# Altium schematic sheet main.SchDoc(main)
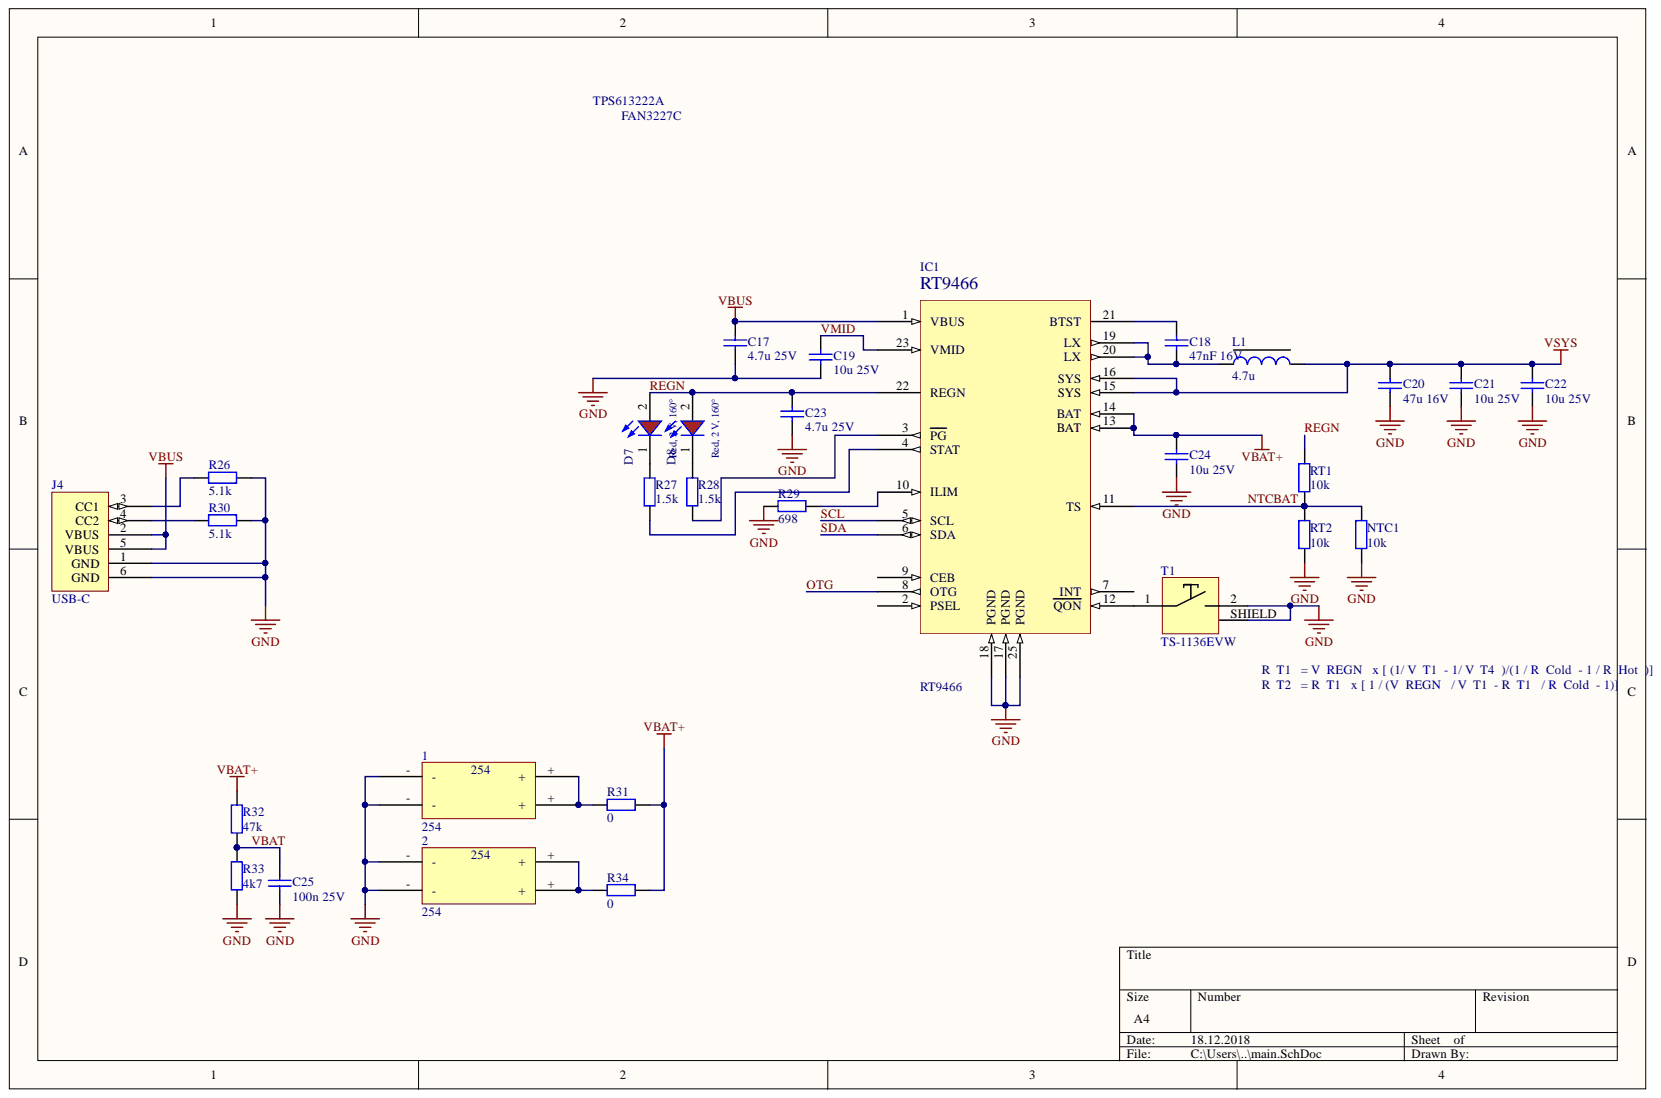
[diagram p0: main.SchDoc]

## Parts
1  254  [254]
2  254  [254]
C17  4.7u 25V  [C-0805-H]
C18  47nF 16V  [C-0603-M]
C19  10u 25V  [C-0805-H]
C20  47u 16V  [C-1210-M]
C21  10u 25V  [C-0805-H]
C22  10u 25V  [C-0805-H]
C23  4.7u 25V  [C-0805-H]
C24  10u 25V  [C-0805-H]
C25  100n 25V  [C-0603-H]
D7  Red, 2 V, 160°  [WL-SMRD_1205_reverse use]
D8  Red, 2 V, 160°  Viewing Angle: 160°  [WL-SMRD_1205_reverse use]
IC1  RT9466  [RT9466]
J4  USB-C  [5362]
L1  4.7u  [LPS4012]
NTC1  10k  [R-0603-H]
R26  5.1k  [R-0603-H]
R27  1.5k  [R-0603-M]
R28  1.5k  [R-0603-M]
R29  698  [R-0603-M]
R30  5.1k  [R-0603-H]
R31  0  [R-1206-M]
R32  47k  [R-0603-H]
R33  4k7  [R-0603-H]
R34  0  [R-1206-M]
RT1  10k  [R-0603-H]
RT2  10k  [R-0603-H]
T1  TS-1136EVW  [TS-1136EVW]

## Nets
GND: 1.- 2.- C17.2 C19.1 C20.1 C21.1 C22.1 C23.1 C24.1 C25.1 IC1.17(PGND) IC1.18(PGND) IC1.25(PGND) J4.1(GND) J4.6(GND) NTC1.1 R26.1 R29.1 R30.1 R33.1 RT2.1 T1.2 T1.SHIELD
VBAT+: C24.2 IC1.13(BAT) IC1.14(BAT) R31.1 R32.2 R34.1
VBUS: C17.1 IC1.1(VBUS) J4.2(VBUS) J4.5(VBUS)
VSYS: C20.2 C21.2 C22.2 IC1.15(SYS) IC1.16(SYS) L1.2
NTCBAT: IC1.11(TS) NTC1.2 RT1.1 RT2.2
OTG: IC1.8(OTG)
REGN: C23.2 D7.2 D8.2 IC1.22(REGN) RT1.2
SCL: IC1.5(SCL)
SDA: IC1.6(SDA)
VBAT: C25.2 R32.1 R33.2
VMID: C19.2 IC1.23(VMID)
Net1_+: 1.+ R31.2
Net2_+: 2.+ R34.2
NetC18_1: C18.1 IC1.19(LX) IC1.20(LX) L1.1
NetC18_2: C18.2 IC1.21(BTST)
NetD7_1: D7.1 R27.1
NetD8_1: D8.1 R28.1
NetIC1_3: IC1.3(PG) R28.2
NetIC1_4: IC1.4(STAT) R27.2
NetIC1_10: IC1.10(ILIM) R29.2
NetIC1_12: IC1.12(QON) T1.1
NetJ4_3: J4.3(CC1) R26.2
NetJ4_4: J4.4(CC2) R30.2

Not connected: IC1 2(PSEL) 7(INT) 9(CEB)

## Library data of the parts
1 2 = 254: Keystone 254; Library Name: 254.SchLib; Component Kind: Standard
C17 C23 = C-0805-4u7-25V: Capacitor 4.7u 25V; Supplier Part Number 1: 80-C0805C475K3P; Supplier 1: Mouser; Library Name: Capacitors-0805.SchLib; Component Kind: Standard
C18 = C-0603-47n-16V: Capacitor 47nF 16V; Library Name: Capacitors-0603.SchLib; Component Kind: Standard
C19 C21 C22 C24 = C-0805-10u-25V: Capacitor 10u 25V; Supplier Part Number 1: 80-C0805C106K3P; Supplier 1: Mouser; Library Name: Capacitors-0805.SchLib; Component Kind: Standard
C20 = C-1210-47u-16V: Capacitor 47uF 16V; Supplier Part Number 1: 80-C1210C476M4P; Supplier 1: Mouser; Library Name: Capacitors-1210.SchLib; Component Kind: Standard
C25 = C-0603-100n-25V: Capacitor 100n 25V; Supplier Part Number 1: 80-C0603C104K3R; Supplier 1: Mouser; Library Name: Capacitors-0603.SchLib; Component Kind: Standard
D7 D8 = 156125RS57000: WL-SMRD SMD Mono-color Reverse mount Diffused, size 1205, Red, 2 V, 160°; Peak Wavelength: 632 nm; Luminous Intensity: 110 mcd; Forward Voltage: 2 V; Dominant Wavelength: 624 nm; Size: 1205; Published Date: May 2018; Part Number: 156125RS57000; Operating Temperature: -40 to 85 degC; Mounting Technology: SMT; Library Name: ALTIUM-WL-SMRD-rev18b.IntLib; Emitting Color: Red; ComponentLink2URL: http://katalog.we-online.de/led/datasheet/156125RS57000.pdf; ComponentLink2Description: Datasheet; ComponentLink1URL: http://www.we-online.de; ComponentLink1Description: Manufacturer; Component Kind: Standard; Publisher: Wurth Elektronik
  D7: Viewing Angle: 160°
J4 = USB-C: USB-C Power only; Library Name: USBC.SchLib; Component Kind: Standard
L1 = L-4u7-LPS4012-472MRB: LPS4012-472MRB; Supplier Part Number 1: 994-LPS4012-472MRB; Supplier 1: Mouser; Library Name: Inductors.SchLib; Component Kind: Standard
NTC1 RT1 RT2 = R-0603-10k: Resistor 10k; Supplier Part Number 1: 71-CRCW0603-10K-E3; Supplier 1: Mouser; Library Name: Resistors-0603.SchLib; Component Kind: Standard
R26 R30 = R-0603-5k1: Resistor 5.1k; Supplier Part Number 1: 71-CRCW0603-5.1K-E3; Supplier 1: Mouser; Library Name: Resistors-0603.SchLib; Component Kind: Standard
R27 R28 = R-0603-1k5: Resistor 1.5k; Supplier Part Number 1: 71-CRCW0603-1.5K-E3; Supplier 1: Mouser; Library Name: Resistors-0603.SchLib; Component Kind: Standard
R29 = R-0603-698: Resistor 698Ohm; Resistance: 0; Supplier 1: Mouser; Library Name: Resistors-0603.SchLib; Component Kind: Standard
R31 R34 = R-1206-0: Resistor 0; Supplier Part Number 1: 71-CRCW1206-0-E3; Supplier 1: Mouser; Library Name: Resistors-1206.SchLib; Component Kind: Standard
R32 = R-0603-47k: Resistor 4k7; Supplier Part Number 1: 71-CRCW060347K0FKEB; Supplier 1: Mouser; Library Name: Resistors-0603.SchLib; Component Kind: Standard
R33 = R-0603-4k7: Resistor 4k7; Supplier Part Number 1: 71-CRCW0603-4.7K-E3; Supplier 1: Mouser; Library Name: Resistors-0603.SchLib; Component Kind: Standard
IC1 = RT9466: Library Name: advanced.SchLib; Component Kind: Standard
T1 = TS-1136EVW: Description: TS-1136EVW; Component Kind: Standard; Library Name: Taster.SchLib
